# Altium schematic sheet Indu_Charge.SchDoc(U_Indu_Charge)
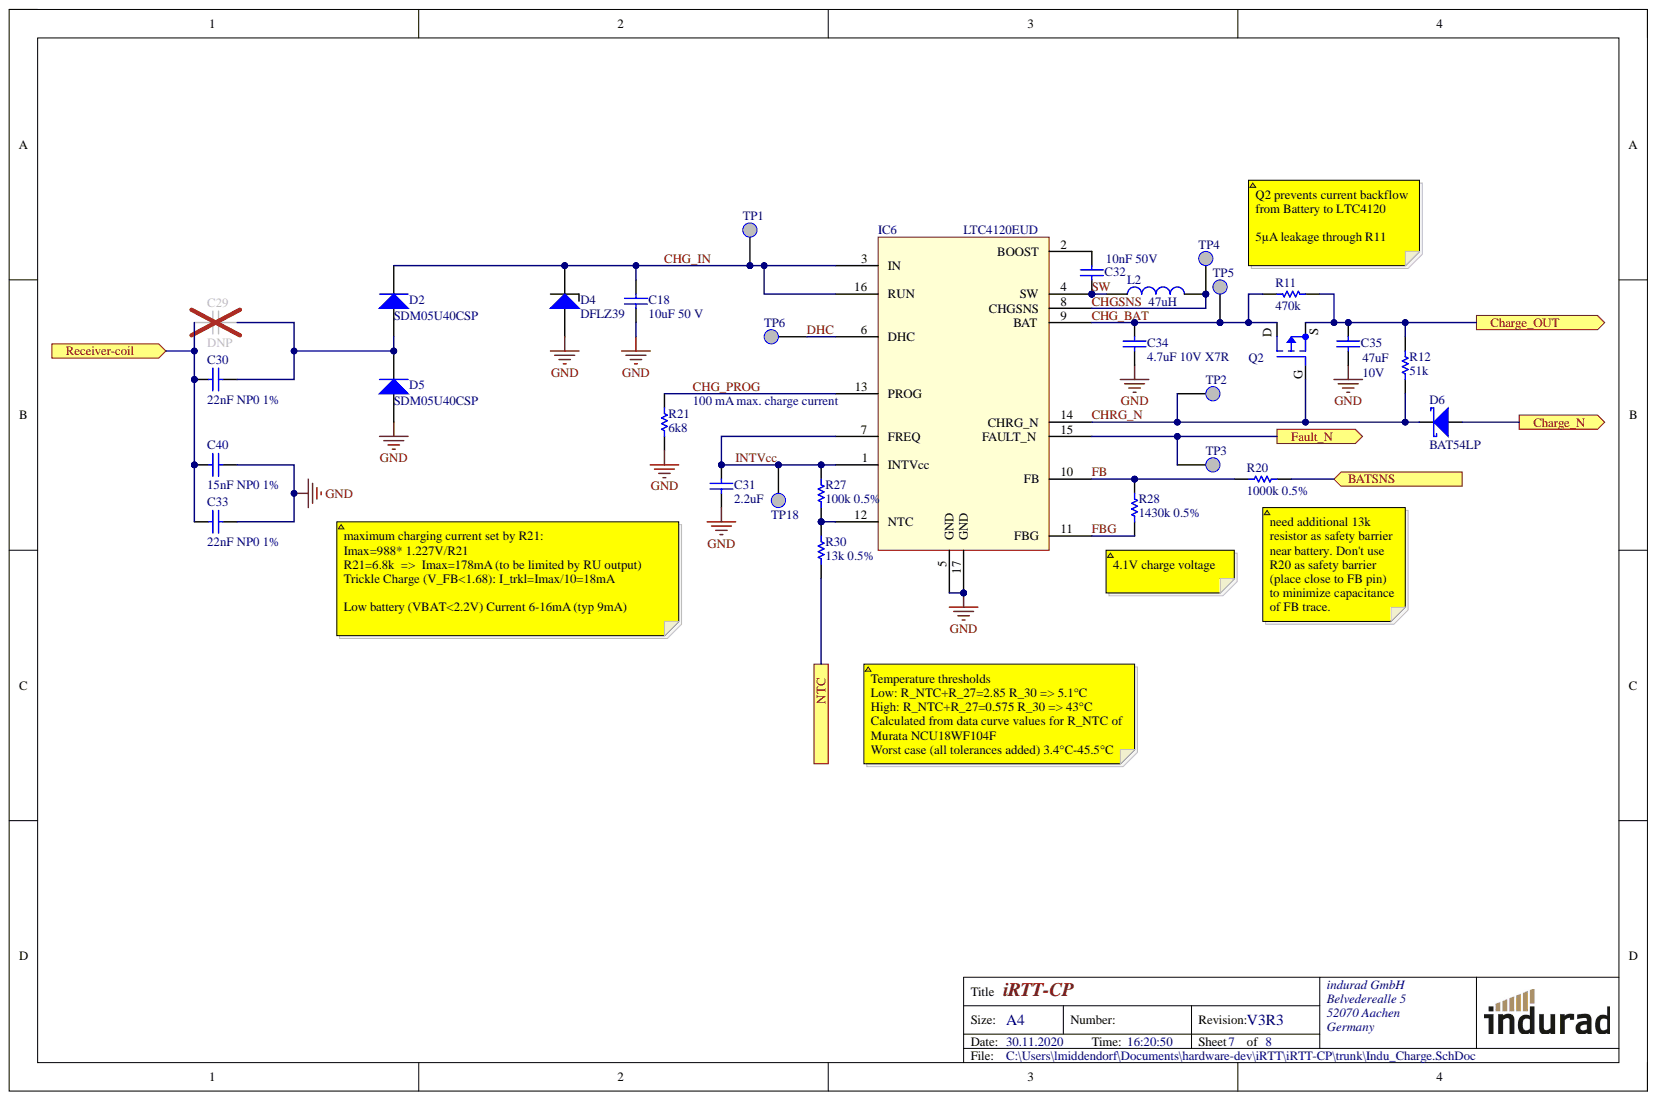
[diagram p0: Indu_Charge.SchDoc]

## Parts
C18  10uF 50 V  [1206_N_(3216)]
C29  DNP  [0603_N_(1608)]
C30  22nF NP0 1%  [0805_N_(2012)]
C31  2.2uF  [0402_N_(1005)]
C32  10nF 50V  [0402_N_(1005)]
C33  22nF NP0 1%  [0805_N_(2012)]
C34  4.7uF 10V X7R  value 4.7uF  [0603_N_(1608)]
C35  47uF 10V  value 47uF  Voltage: 10V  [1206_N_(3216)]
C40  15nF NP0 1%  [0805_N_(2012)]
D2  SDM05U40CSP  [2-XDFN]
D4  DFLZ39  [PowerDI]
D5  SDM05U40CSP  [2-XDFN]
D6  BAT54LP  [DFN1006-2]
IC6  LTC4120EUD  [QFN16]
L2  Inductance: 47uH  [WE-MAIA_3015]  (hidden comment: IND-WE-74438335470)
Q2  P-MOSFET  [SOT883]  (value not drawn)
R11  470k  [0402_N_(1005)]
R12  51k  [0201_N_(0603)]
R20  1000k 0.5%  [0402_N_(1005)]
R21  6k8  [0402_N_(1005)]
R27  100k 0.5%  [0402_N_(1005)]
R28  1430k 0.5%  [0402_N_(1005)]
R30  13k 0.5%  [0402_N_(1005)]
TP1  TP  [TP1.0d0.7]  (no BOM)
TP2  TP  [TP1.0d0.7]  (no BOM)
TP3  TP  [TP1.0d0.7]  (no BOM)
TP4  TP  [TP1.0d0.7]  (no BOM)
TP5  TP  [TP1.0d0.7]  (no BOM)
TP6  TP  [TP0.6d0.3]  (no BOM)
TP18  TP  [TP0.6d0.3]  (no BOM)

## Ports
BATSNS, Charge_N, Charge_OUT, Fault_N, NTC, Receiver-coil

## Nets
GND: C18.1 C31.1 C33.1 C34.1 C35.1 C40.1 D4.2 D5.2 IC6.5(GND) IC6.17(GND) R21.1
BATSNS: R20.1 ; port BATSNS
Charge_N: D6.2 ; port Charge_N
Charge_OUT: C35.2 Q2.2 R11.1 R12.1 ; port Charge_OUT
CHG_BAT: C34.2 IC6.9(BAT) Q2.3 R11.2 TP5.1
CHG_IN: C18.2 D2.1 D4.1 IC6.3(IN) IC6.16(RUN) TP1.1
CHG_PROG: IC6.13(PROG) R21.2
CHGSNS: IC6.8(CHGSNS) L2.2 TP4.1
CHRG_N: D6.1 IC6.14(CHRG_N) Q2.1 R12.2 TP2.1
DHC: IC6.6(DHC) TP6.1
Fault_N: IC6.15(FAULT_N) TP3.1 ; port Fault_N
FB: IC6.10(FB) R20.2 R28.1
FBG: IC6.11(FBG) R28.2
INTVcc: C31.2 IC6.1(INTVcc) IC6.7(FREQ) R27.1 TP18.1
NTC: R30.2 ; port NTC
Receiver-coil: C29.2 C30.2 C33.2 C40.2 ; port Receiver-coil
SW: C32.2 IC6.4(SW) L2.1
NetC29_1: C29.1 C30.1 D2.2 D5.1
NetC32_1: C32.1 IC6.2(BOOST)
NetIC6_12: IC6.12(NTC) R27.2 R30.1

## Library data of the parts
C18 = Cap: Capacitor; Manufacturer: Murata Electronics North America; Manufacturer Part Number: GRM31CR61H106KA12L; Notification: Current market demand for these product types have resulted in fluctuating and extending lead times. Lead times may differ.; Temperature Coefficient: X5R; Tolerance: ±10%; Voltage - Rated: 50V; Applications: General Purpose; Category: Capacitors; Component Kind: Standard; ComponentLink1Description: https://www.murata.com/~/media/webrenewal/support/library/catalog/products/capacitor/mlcc/c02e.ashx?la=en-us; ComponentLink1URL: https://www.murata.com/~/media/webrenewal/support/library/catalog/products/capacitor/mlcc/c02e.ashx?la=en-us; Features: -; Height - Seated (Max): -; Lead Spacing: -; Lead Style: -; Mounting Type: Surface Mount, MLCC; Operating Temperature: -55°C ~ 85°C; Package / Case: 1206 (3216 Metric); Packaging: Cut Tape (CT); Part Status: Active; Pricing 1: 1=0.57, 10=0.404, 100=0.2662, 500=0.19716, 1000=0.16758 (EUR); Ratings: -; RoHS: RoHS Compliant; Signal Integrity: Cap; Simulation: CAP; Size / Dimension: 0.126" L x 0.063" W (3.20mm x 1.60mm); Stock 1: 105231; Supplier 1: Digi-Key; Supplier Part Number 1: 490-5961-1-ND; Thickness (Max): 0.071" (1.80mm); Value: 10uF 50 V; Library Name: Indurad.SchLib
C29 C34 C35 = Cap: Capacitor; Component Kind: Standard; Signal Integrity: Cap; Simulation: CAP; Library Name: Indurad.SchLib
  C29: Value: DNP
  C34: Dielectric: X7R; Voltage: 10V
C30 C33 = Cap: Capacitor; Manufacturer: KEMET; Manufacturer Part Number: C0805C223F5GACTU; Notification: Current market demand for these product types have resulted in fluctuating and extending lead times. Lead times may differ.; Temperature Coefficient: C0G, NP0; Voltage - Rated: 50V; Applications: General Purpose; Category: Capacitors; Component Kind: Standard; ComponentLink1Description: https://search.kemet.com/component-edge/download/datasheet/C0805C223F5GACTU.pdf; ComponentLink1URL: https://search.kemet.com/component-edge/download/datasheet/C0805C223F5GACTU.pdf; Failure Rate: -; Features: Low ESL; Height - Seated (Max): -; Lead Spacing: -; Lead Style: -; Mounting Type: Surface Mount, MLCC; Operating Temperature: -55°C ~ 125°C; Package / Case: 0805 (2012 Metric); Packaging: Cut Tape (CT); Part Status: Active; Pricing 1: 1=2.53, 10=2.041, 100=1.5587, 500=1.18756, 1000=1.03911 (EUR); Ratings: -; RoHS: RoHS Compliant; Signal Integrity: Cap; Simulation: CAP; Size / Dimension: 0.079" L x 0.049" W (2.00mm x 1.25mm); Stock 1: 34876; Supplier 1: Digi-Key; Supplier Part Number 1: 399-10067-1-ND; Thickness (Max): 0.047" (1.20mm); Tolerance: ±1%; Value: 22nF NP0 1%; Library Name: Indurad.SchLib
C31 = Cap: Capacitor; Manufacturer: Murata Electronics North America; Manufacturer Part Number: GRM155R60J225ME15D; Notification: Current market demand for these product types have resulted in fluctuating and extending lead times. Lead times may differ.; Temperature Coefficient: X5R; Tolerance: ±20%; Voltage - Rated: 6.3V; Applications: General Purpose; Category: Capacitors; Component Kind: Standard; ComponentLink1Description: https://www.murata.com/~/media/webrenewal/support/library/catalog/products/capacitor/mlcc/c02e.ashx?la=en-us; ComponentLink1URL: https://www.murata.com/~/media/webrenewal/support/library/catalog/products/capacitor/mlcc/c02e.ashx?la=en-us; Features: Derating Recommended; Height - Seated (Max): -; Lead Spacing: -; Lead Style: -; Mounting Type: Surface Mount, MLCC; Operating Temperature: -55°C ~ 85°C; Package / Case: 0402 (1005 Metric); Packaging: Cut Tape (CT); Part Status: Active; Pricing 1: 1=0.13, 10=0.098, 100=0.046, 500=0.0323, 1000=0.02673, 2500=0.02488, 5000=0.02276 (EUR); Ratings: -; RoHS: RoHS Compliant; Signal Integrity: Cap; Simulation: CAP; Size / Dimension: 0.039" L x 0.020" W (1.00mm x 0.50mm); Stock 1: 1516638; Supplier 1: Digi-Key; Supplier Part Number 1: 490-4519-1-ND; Thickness (Max): 0.022" (0.55mm); Value: 2.2uF; Library Name: Indurad.SchLib
C32 = Cap: Capacitor; Manufacturer: Murata Electronics North America; Manufacturer Part Number: GRM155R71H103KA88D; Notification: Current market demand for these product types have resulted in fluctuating and extending lead times. Lead times may differ.; Temperature Coefficient: X7R; Tolerance: ±10%; Applications: General Purpose; Category: Capacitors; Component Kind: Standard; ComponentLink1Description: https://www.murata.com/~/media/webrenewal/support/library/catalog/products/capacitor/mlcc/c02e.ashx?la=en-us; ComponentLink1URL: https://www.murata.com/~/media/webrenewal/support/library/catalog/products/capacitor/mlcc/c02e.ashx?la=en-us; Features: -; Height - Seated (Max): -; Lead Spacing: -; Lead Style: -; Mounting Type: Surface Mount, MLCC; Operating Temperature: -55°C ~ 125°C; Package / Case: 0402 (1005 Metric); Packaging: Cut Tape (CT); Part Status: Active; Pricing 1: 1=0.08, 10=0.009, 100=0.0042, 500=0.00296, 1000=0.00233, 2500=0.00212, 5000=0.00195 (EUR); Ratings: -; RoHS: RoHS Compliant; Signal Integrity: Cap; Simulation: CAP; Size / Dimension: 0.039" L x 0.020" W (1.00mm x 0.50mm); Stock 1: 4260000; Supplier 1: Digi-Key; Supplier Part Number 1: 490-4516-1-ND; Thickness (Max): 0.022" (0.55mm); Value: 10nF 50V; Voltage - Rated: 50V; Library Name: Indurad.SchLib
C40 = Cap: Capacitor; Manufacturer: KEMET; Manufacturer Part Number: C0805C153F5GACTU; Temperature Coefficient: C0G, NP0; Voltage - Rated: 50V; Applications: General Purpose; Category: Capacitors; Component Kind: Standard; ComponentLink1Description: https://search.kemet.com/component-edge/download/datasheet/C0805C223F5GACTU.pdf; ComponentLink1URL: https://search.kemet.com/component-edge/download/datasheet/C0805C223F5GACTU.pdf; Failure Rate: -; Features: Low ESL; Height - Seated (Max): -; Lead Spacing: -; Lead Style: -; Mounting Type: Surface Mount, MLCC; Operating Temperature: -55°C ~ 125°C; Package / Case: 0805 (2012 Metric); Packaging: Cut Tape (CT); Part Status: Active; Ratings: -; RoHS: RoHS Compliant; Signal Integrity: Cap; Simulation: CAP; Size / Dimension: 0.079" L x 0.049" W (2.00mm x 1.25mm); Thickness (Max): 0.047" (1.20mm); Tolerance: ±1%; Value: 15nF NP0 1%; Library Name: Indurad.SchLib
D2 D5 = SDM05U40CSP: DIODE SCHOTTKY 40V 0.5 A 2-XDFN; Manufacturer: Diodes Incorporated; Manufacturer Part Number: SDM05U40CSP-7; Capacitance @ Vr, F: 35pF @ 4V, 1MHz; Current - Average Rectified (Io): 500mA; Current - Reverse Leakage @ Vr: 75µA @ 40V; Speed: Fast Recovery =< 500ns, > 200mA (Io); Category: Discrete Semiconductor Products; Component Kind: Standard; ComponentLink1Description: https://www.diodes.com/assets/Datasheets/SDM05U40CSP.pdf; ComponentLink1URL: https://www.diodes.com/assets/Datasheets/SDM05U40CSP.pdf; Diode Type: Schottky; Mounting Type: Surface Mount; Operating Temperature - Junction: -55°C ~ 150°C; Package / Case: 2-XDFN; Packaging: Cut Tape (CT); Part Status: Active; Pricing 1: 1=0.36, 10=0.265, 100=0.1503, 500=0.09956, 1000=0.07634 (EUR); RoHS: RoHS Compliant; Stock 1: 3397; Supplier 1: Digi-Key; Supplier Device Package: X3-WLB1006-2; Supplier Part Number 1: SDM05U40CSP-7DICT-ND; Library Name: Indurad.SchLib
D4 = DFLZ39: DIODE ZENER 39V 1W POWERDI123; Manufacturer: Diodes Incorporated; Manufacturer Part Number: DFLZ39-7; Current - Reverse Leakage @ Vr: 1µA @ 30V; Tolerance: ±5%; Voltage - Forward (Vf) (Max) @ If: 1.2V @ 200mA; Category: Discrete Semiconductor Products; Component Kind: Standard; ComponentLink1Description: https://www.diodes.com/assets/Datasheets/ds30464.pdf; ComponentLink1URL: https://www.diodes.com/assets/Datasheets/ds30464.pdf; Mounting Type: Surface Mount; Operating Temperature: -55°C ~ 150°C; Package / Case: POWERDI®123; Packaging: Cut Tape (CT); Part Status: Active; Pricing 1: 1=0.44, 10=0.357, 100=0.2427, 500=0.18208, 1000=0.13656 (EUR); RoHS: RoHS Compliant; Stock 1: 20999; Supplier 1: Digi-Key; Supplier Device Package: PowerDI™ 123; Supplier Part Number 1: DFLZ39DICT-ND; Library Name: Indurad.SchLib
D6 = BAT54LP: Schottky Diode 30V 200mA; Manufacturer: Diodes; Manufacturer Part Number: BAT54LP-7; Capacitance: 10pF; Element Configuration: Single; Forward Voltage: 1V; Max Forward Surge Current (Ifsm): 600mA; Peak Reverse Current: 2uA; Polarity: Standard; Power Dissipation: 250mW; Reverse Recovery Time: 5ns; Average Rectified Current: 200mA; Case/Package: DFN; Component Kind: Standard; ComponentLink1Description: infopage; ComponentLink1URL: https://www.digikey.com/product-detail/en/diodes-incorporated/BAT54LP-7/BAT54LPDICT-ND/765633; ComponentLink2Description: datasheet; ComponentLink2URL: https://www.diodes.com/assets/Datasheets/ds30504.pdf; Contact Plating: Gold; Current Rating: 200mA; Forward Current: 200mA; Height: 0.47mm; Lead Free: Lead Free; Length: 1mm; Library Name: D_Diode.SchLib; Max Operating Temperature: 125°C; Max Repetitive Reverse Voltage (Vrrm): 30V; Max Reverse Voltage (DC): 30V; Min Operating Temperature: -65°C; Mount: Surface Mount; Number of Pins: 2; Output Current: 200mA; Package Quantity: 3000; Packaging: Tape and Reel; Peak Non-Repetitive Surge Current: 600mA; Power Rating: 250mW; Radiation Hardening: No; REACH SVHC: No SVHC; Supplier: Arrow Europe; Supplier 1: Digi-Key; Supplier 2: Mouser; Supplier 3: Farnell; Supplier 4: Arrow Europe; Supplier Part Number: BAT54LP-7; Supplier Part Number 1: BAT54LPDIDKR-ND; Supplier Part Number 2: 621-BAT54LP-7; Supplier Part Number 3: 1858664RL; Supplier Part Number 4: BAT54LP-7; Voltage Rating (DC): 30V; Width: 0.6mm
IC6 = LTC4120: Wireless Power Receiver and  400mA Buck Battery Charger; Manufacturer: Analog Devices / Linear Technology; Manufacturer Part Number: LTC4120EUD#PBF; Battery Pack Voltage: 11V; Max Supply Voltage: 40V; Min Supply Voltage: 4.25V; Number of Cells: 2; Case/Package: QFN; Component Kind: Standard; ComponentLink1Description: http://www.linear.com/docs/43861; ComponentLink1URL: http://www.linear.com/docs/43861; Library Name: IC_Integrated_Circuit.SchLib; Max Charge Current: 400mA; Max Operating Temperature: 125°C; Min Operating Temperature: -40°C; Mount: Surface Mount; Number of Pins: 16; Packaging: Rail/Tube; REACH SVHC: No SVHC; RoHS: Yes; Supplier 1: Digi-Key; Supplier 2: Mouser; Supplier Part Number 1: LTC4120EUD#PBF-ND; Supplier Part Number 2: 584-LTC4120EUD#PBF
L2 = IND-WE-78438335470: WE-MAPI SMD Power Inductor, size 3015, 47 uH; Manufacturer: Wurth Electronics; Core Material: Metal,Composite,Ferrite; Current Rating: 390mA; DC Resistance (DCR): 2.3R; Inductance Tolerance: 20%; Saturation Current: 1.18A; Self Resonant Frequency: 8MHz; Series Resistance: 2.09R; Shielding: Shielded; Test Frequency: 100kHz; Case Code (Imperial): 1212; Case Code (Metric): 3030; Case/Package: SMD/SMT; Component Kind: Standard; ComponentLink1Description: Data Sheet; ComponentLink1URL: https://www.we-online.com/catalog/datasheet/74438335470.pdf; Depth: 3mm; Height: 1.5mm; Height - Seated (Max): 0.059inch; Length: 3mm; Manufacturer Part Number: 74438335470; Max DC Current: 390mA; Max Operating Temperature: 125°C; Min Operating Temperature: -40°C; Mount: Surface Mount; Package Quantity: 1000; Packaging: Tape and Reel; Pricing 1: 1=1,57000, 10=1,54600, 50=1,44300, 100=1,34000, 500=1,23600 (USD); Pricing 2: 1=1,57000, 10=1,54000, 25=1,54000, 50=1,44000, 100=1,34000, 250=1,34000, 500=1,23000, 1000=1,13000, 2000=1,05000 (USD); REACH SVHC: Unknown; RMS Current (Irms): 390mA; RoHS: Yes; Supplier 1: Digi-Key; Supplier 2: Mouser; Supplier Part Number 1: 732-4872-1-ND; Supplier Part Number 2: 710-74438335470; Termination: SMD/SMT; Tolerance: 20%; Width: 0.118inch; Library Name: Indurad.SchLib
Q2 = MOSFET-P SSM3J56ACT: P-Channel MOSFET SSM3J56ACT; Manufacturer: Toshiba Semiconductor and Storage; Manufacturer Part Number: SSM3J56ACT,L3F; Current - Continuous Drain (Id) @ 25°C: 1.4A (Ta); Drive Voltage (Max Rds On,  Min Rds On): 1.2V, 4.5V; Gate Charge (Qg) (Max) @ Vgs: 1.6nC @ 4.5V; Input Capacitance (Ciss) (Max) @ Vds: 100pF @ 10V; Power Dissipation (Max): 500mW (Ta); Rds On (Max) @ Id, Vgs: 390 mOhm @ 800mA, 4.5V; Vgs (Max): ±8V; Vgs(th) (Max) @ Id: 1V @ 1mA; Category: Discrete Semiconductor Products; Component Kind: Standard; ComponentLink1Description: https://toshiba.semicon-storage.com/info/docget.jsp?did=30735&prodName=SSM3J56ACT; ComponentLink1URL: https://toshiba.semicon-storage.com/info/docget.jsp?did=30735&prodName=SSM3J56ACT; FET Feature: -; FET Type: P-Channel; Library Name: Q_Transistor.SchLib; Mounting Type: Surface Mount; Operating Temperature: 150°C; Package / Case: SC-101, SOT-883; Packaging: Cut Tape (CT); Part Status: Active; Pricing 1: 1=0.42, 10=0.292, 100=0.1919, 500=0.11342, 1000=0.08725 (EUR); RoHS: RoHS Compliant; Stock 1: 28265; Supplier 1: Digi-Key; Supplier 2: Arrow; Supplier 3: Mouser; Supplier Device Package: CST3; Supplier Part Number 1: SSM3J56ACTL3FCT-ND; Supplier Part Number 2: SSM3J56ACT,L3F; Supplier Part Number 3: 757-SSM3J56ACTL3F; Technology: MOSFET (Metal Oxide)
R11 R12 R20 R21 R27 R28 R30 = Res: Resistor; Component Kind: Standard; Signal Integrity: Res; Simulation: RESISTOR; Library Name: Indurad.SchLib
  R11: Value: 470k
  R12: Value: 51k
  R20: Value: 1000k 0.5%
  R21: Value: 6k8
  R27: Value: 100k 0.5%
  R28: Value: 1430k 0.5%
  R30: Value: 13k 0.5%
TP1-TP6 TP18 = TP: Generic test point; Library Name: InduradCommon.SchLib
